# Altium schematic sheet DE1_SIDE_SDRAM.schdoc
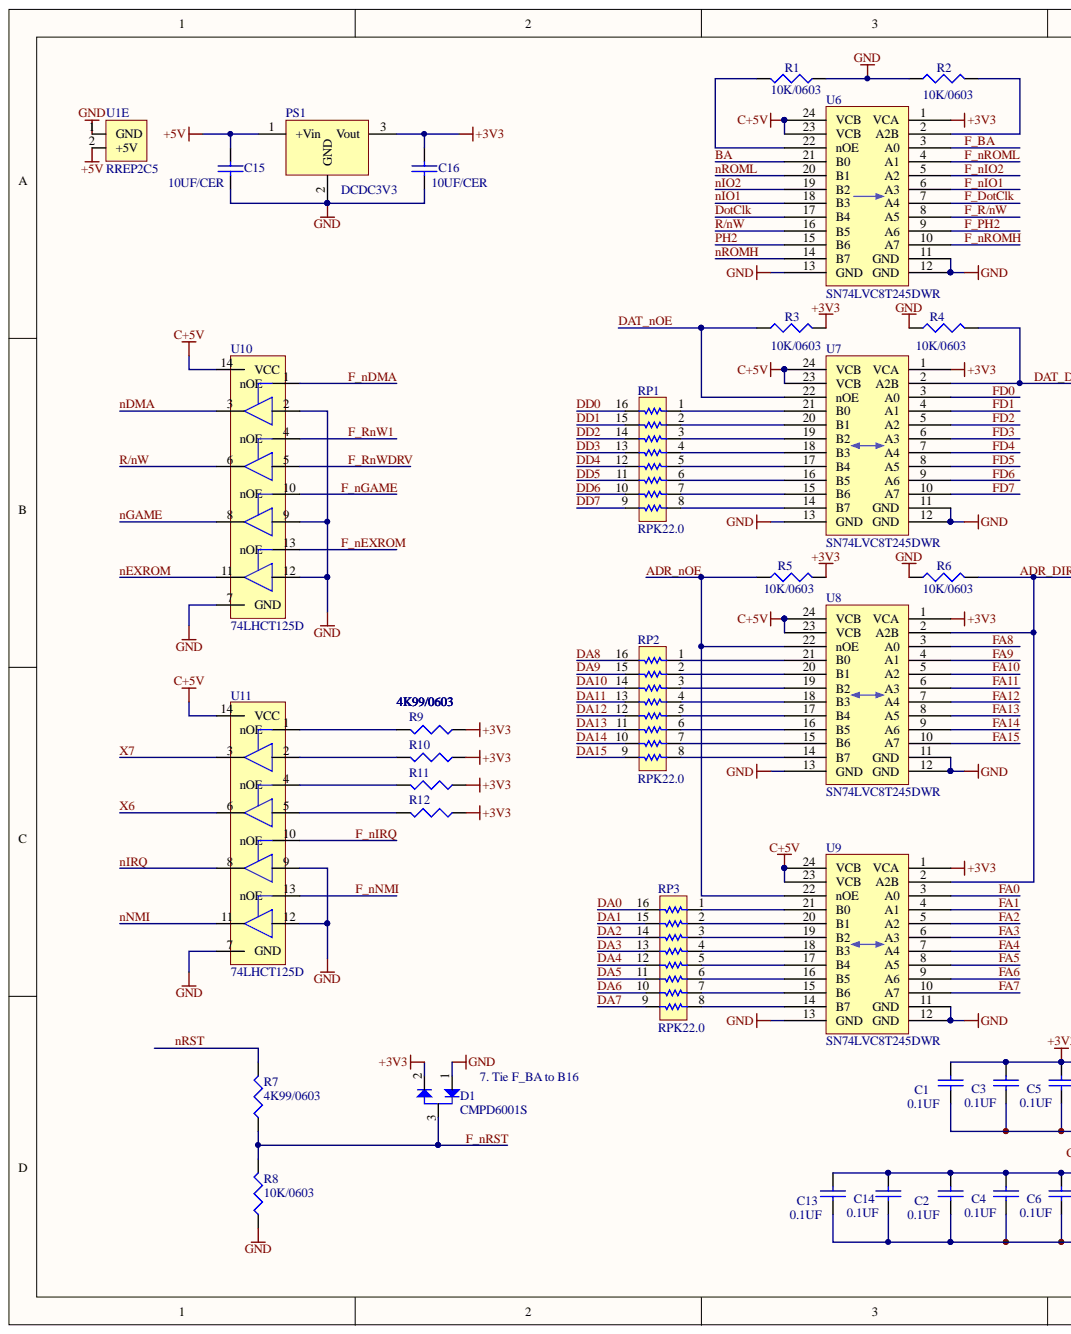
[diagram p0: DE1_SIDE_SDRAM.schdoc, left]
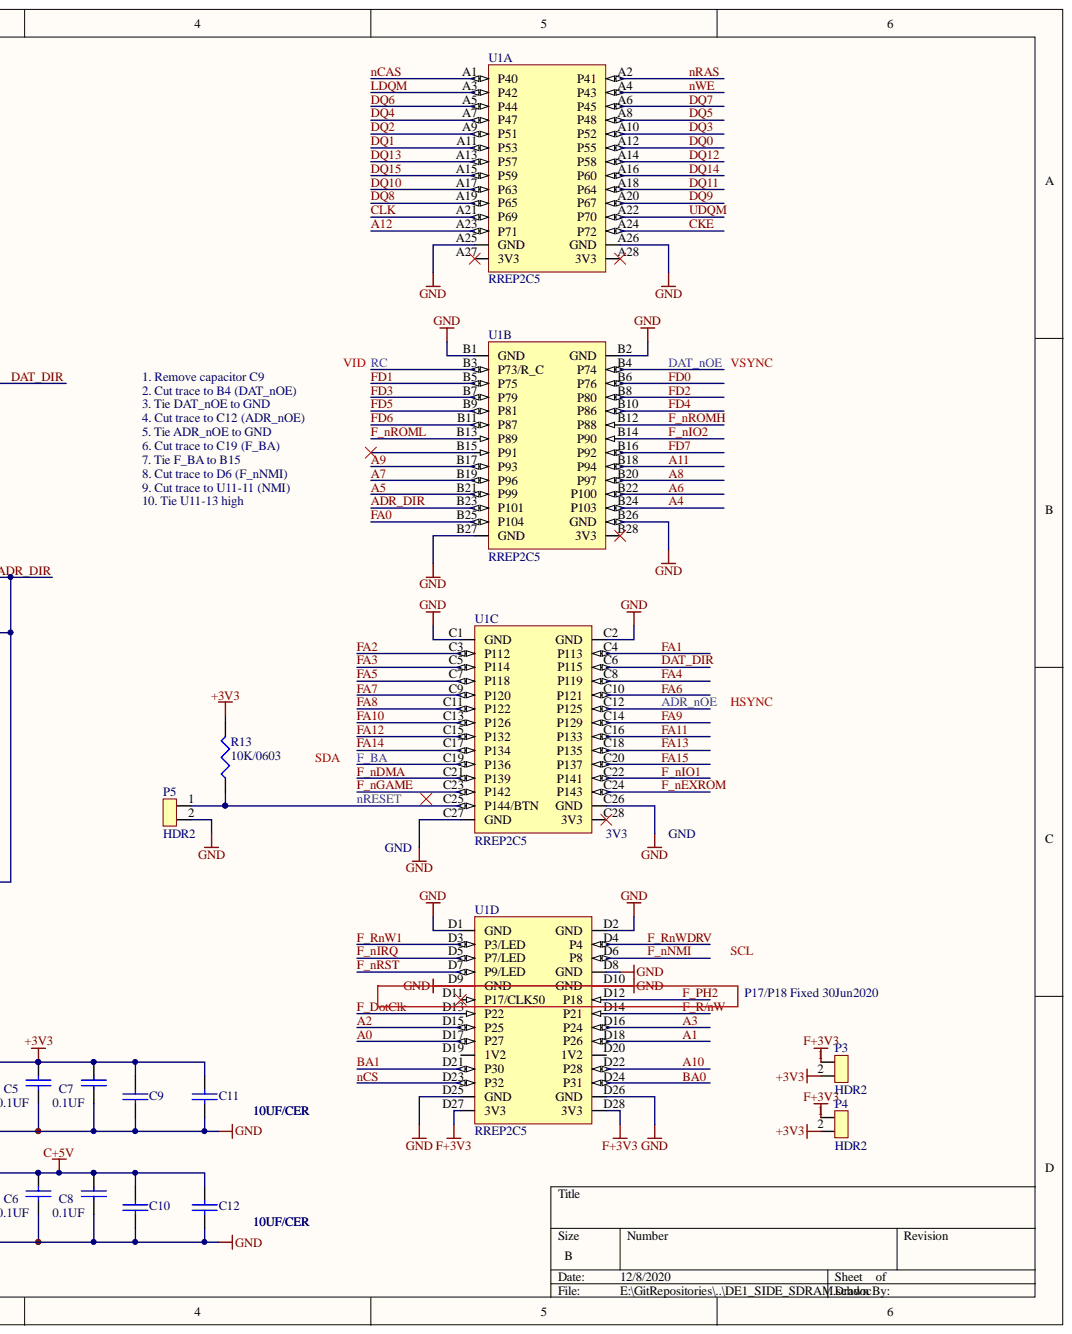
[diagram p1: DE1_SIDE_SDRAM.schdoc, right]

## Parts
C1  0.1UF  [0603]
C2  0.1UF  [0603]
C3  0.1UF  [0603]
C4  0.1UF  [0603]
C5  0.1UF  [0603]
C6  0.1UF  [0603]
C7  0.1UF  [0603]
C8  0.1UF  [0603]
C9  10UF/CER  [0805]  (value not drawn)
C10  10UF/CER  [0805]  (value not drawn)
C11  10UF/CER  [0805]
C12  10UF/CER  [0805]
C13  0.1UF  [0603]
C14  0.1UF  [0603]
C15  10UF/CER  [0805]
C16  10UF/CER  [0805]
D1  CMPD6001S  [SOT23]
P3  HDR2  [HDR2]
P4  HDR2  [HDR2]
P5  HDR2  [HDR2]
PS1  DCDC3V3  [CUI_P7805]
R1  10K/0603  [0603]
R2  10K/0603  [0603]
R3  10K/0603  [0603]
R4  10K/0603  [0603]
R5  10K/0603  [0603]
R6  10K/0603  [0603]
R7  4K99/0603  [0603]
R8  10K/0603  [0603]
R9  4K99/0603  [0603]
R10  4K99/0603  [0603]
R11  4K99/0603  [0603]
R12  4K99/0603  [0603]
R13  10K/0603  [0603]
RP1  RPK22.0  [RPK4816]
RP2  RPK22.0  [RPK4816]
RP3  RPK22.0  [RPK4816]
U1  RREP2C5  [RR2C5]  (parts A,B,C,D,E)
U6  SN74LVC8T245DWR  [SOL-24]
U7  SN74LVC8T245DWR  [SOL-24]
U8  SN74LVC8T245DWR  [SOL-24]
U9  SN74LVC8T245DWR  [SOL-24]
U10  74LHCT125D  [SOP14]
U11  74LHCT125D  [SOP14]

## Nets
+3V3: C1.1 C3.1 C5.1 C7.1 C9.2 C11.2 C16.2 D1.2 P3.2 P4.2 PS1.3 R3.2 R5.2 R9.1 R10.1 R11.1 R12.1 R13.2 U6.1(VCA) U7.1(VCA) U8.1(VCA) U9.1(VCA)
+5V: C15.2 PS1.1 U1.2(+5V)
C+5V: C2.1 C4.1 C6.1 C8.1 C10.2 C12.2 C13.1 C14.1 U6.23(VCB) U6.24(VCB) U7.23(VCB) U7.24(VCB) U8.23(VCB) U8.24(VCB) U9.23(VCB) U9.24(VCB) U10.14(VCC) U11.14(VCC)
F+3V3: P3.1 P4.1 U1.D27(3V3) U1.D28(3V3)
GND: C1.2 C2.2 C3.2 C4.2 C5.2 C6.2 C7.2 C8.2 C9.1 C10.1 C11.1 C12.1 C13.2 C14.2 C15.1 C16.1 D1.1 P5.2 PS1.2 R1.1 R2.1 R4.1 R6.1 R8.1 U1.1(GND) U1.A25(GND) U1.A26(GND) U1.B1(GND) U1.B2(GND) U1.B26(GND) U1.B27(GND) U1.C1(GND) U1.C2(GND) U1.C26(GND) U1.C27(GND) U1.D1(GND) U1.D2(GND) U1.D8(GND) U1.D9(GND) U1.D10(GND) U1.D25(GND) U1.D26(GND) U6.11(GND) U6.12(GND) U6.13(GND) U7.11(GND) U7.12(GND) U7.13(GND) U8.11(GND) U8.12(GND) U8.13(GND) U9.11(GND) U9.12(GND) U9.13(GND) U10.2 U10.7(GND) U10.9 U10.12 U11.7(GND) U11.9 U11.12
A0: U1.D17(P27)
A1: U1.D18(P26)
A2: U1.D15(P25)
A3: U1.D16(P24)
A4: U1.B24(P103)
A5: U1.B21(P99)
A6: U1.B22(P100)
A7: U1.B19(P96)
A8: U1.B20(P97)
A9: U1.B17(P93)
A10: U1.D22(P28)
A11: U1.B18(P94)
A12: U1.A23(P71)
ADR_DIR: R6.2 U1.B23(P101) U8.2(A2B) U9.2(A2B)
ADR_NOE: R5.1 U1.C12(P125) U8.22(nOE) U9.22(nOE)
BA: U6.21(B0)
BA0: U1.D24(P31)
BA1: U1.D21(P30)
CKE: U1.A24(P72)
CLK: U1.A21(P69)
DA0: RP3.16
DA1: RP3.15
DA2: RP3.14
DA3: RP3.13
DA4: RP3.12
DA5: RP3.11
DA6: RP3.10
DA7: RP3.9
DA8: RP2.16
DA9: RP2.15
DA10: RP2.14
DA11: RP2.13
DA12: RP2.12
DA13: RP2.11
DA14: RP2.10
DA15: RP2.9
DAT_DIR: R4.2 U1.C6(P115) U7.2(A2B)
DAT_NOE: R3.1 U1.B4(P74) U7.22(nOE)
DD0: RP1.16
DD1: RP1.15
DD2: RP1.14
DD3: RP1.13
DD4: RP1.12
DD5: RP1.11
DD6: RP1.10
DD7: RP1.9
DOTCLK: U6.17(B4)
DQ0: U1.A12(P55)
DQ1: U1.A11(P53)
DQ2: U1.A9(P51)
DQ3: U1.A10(P52)
DQ4: U1.A7(P47)
DQ5: U1.A8(P48)
DQ6: U1.A5(P44)
DQ7: U1.A6(P45)
DQ8: U1.A19(P65)
DQ9: U1.A20(P67)
DQ10: U1.A17(P63)
DQ11: U1.A18(P64)
DQ12: U1.A14(P58)
DQ13: U1.A13(P57)
DQ14: U1.A16(P60)
DQ15: U1.A15(P59)
F_BA: U1.C19(P136) U6.3(A0)
F_DOTCLK: U1.D13(P22) U6.7(A4)
F_NDMA: U1.C21(P139) U10.1(nOE)
F_NEXROM: U1.C24(P143) U10.13(nOE)
F_NGAME: U1.C23(P142) U10.10(nOE)
F_NIO1: U1.C22(P141) U6.6(A3)
F_NIO2: U1.B14(P90) U6.5(A2)
F_NIRQ: U1.D5(P7/LED) U11.10(nOE)
F_NNMI: U1.D6(P8) U11.13(nOE)
F_NROMH: U1.B12(P88) U6.10(A7)
F_NROML: U1.B13(P89) U6.4(A1)
F_NRST: D1.3 R7.1 R8.2 U1.D7(P9/LED)
F_PH2: U1.D12(P18) U6.9(A6)
F_R/NW: U1.D14(P21) U6.8(A5)
F_RNW1: U1.D3(P3/LED) U10.4(nOE)
F_RNWDRV: U1.D4(P4) U10.5
FA0: U1.B25(P104) U9.3(A0)
FA1: U1.C4(P113) U9.4(A1)
FA2: U1.C3(P112) U9.5(A2)
FA3: U1.C5(P114) U9.6(A3)
FA4: U1.C8(P119) U9.7(A4)
FA5: U1.C7(P118) U9.8(A5)
FA6: U1.C10(P121) U9.9(A6)
FA7: U1.C9(P120) U9.10(A7)
FA8: U1.C11(P122) U8.3(A0)
FA9: U1.C14(P129) U8.4(A1)
FA10: U1.C13(P126) U8.5(A2)
FA11: U1.C16(P133) U8.6(A3)
FA12: U1.C15(P132) U8.7(A4)
FA13: U1.C18(P135) U8.8(A5)
FA14: U1.C17(P134) U8.9(A6)
FA15: U1.C20(P137) U8.10(A7)
FD0: U1.B6(P76) U7.3(A0)
FD1: U1.B5(P75) U7.4(A1)
FD2: U1.B8(P80) U7.5(A2)
FD3: U1.B7(P79) U7.6(A3)
FD4: U1.B10(P86) U7.7(A4)
FD5: U1.B9(P81) U7.8(A5)
FD6: U1.B11(P87) U7.9(A6)
FD7: U1.B16(P92) U7.10(A7)
LDQM: U1.A3(P42)
NCAS: U1.A1(P40)
NCS: U1.D23(P32)
NDMA: U10.3
NEXROM: U10.11
NGAME: U10.8
NIO1: U6.18(B3)
NIO2: U6.19(B2)
NIRQ: U11.8
NNMI: U11.11
NRAS: U1.A2(P41)
NRESET: P5.1 R13.1 U1.C25(P144/BTN)
NROMH: U6.14(B7)
NROML: U6.20(B1)
NRST: R7.2
NWE: U1.A4(P43)
PH2: U6.15(B6)
R/NW: U6.16(B5) U10.6
RC: U1.B3(P73/R_C)
UDQM: U1.A22(P70)
X6: U11.6
X7: U11.3
NetR1_2: R1.2 U6.22(nOE)
NetR2_2: R2.2 U6.2(A2B)
NetR9_2: R9.2 U11.1(nOE)
NetR10_2: R10.2 U11.2
NetR11_2: R11.2 U11.4(nOE)
NetR12_2: R12.2 U11.5
NetRP1_1: RP1.1 U7.21(B0)
NetRP1_2: RP1.2 U7.20(B1)
NetRP1_3: RP1.3 U7.19(B2)
NetRP1_4: RP1.4 U7.18(B3)
NetRP1_5: RP1.5 U7.17(B4)
NetRP1_6: RP1.6 U7.16(B5)
NetRP1_7: RP1.7 U7.15(B6)
NetRP1_8: RP1.8 U7.14(B7)
NetRP2_1: RP2.1 U8.21(B0)
NetRP2_2: RP2.2 U8.20(B1)
NetRP2_3: RP2.3 U8.19(B2)
NetRP2_4: RP2.4 U8.18(B3)
NetRP2_5: RP2.5 U8.17(B4)
NetRP2_6: RP2.6 U8.16(B5)
NetRP2_7: RP2.7 U8.15(B6)
NetRP2_8: RP2.8 U8.14(B7)
NetRP3_1: RP3.1 U9.21(B0)
NetRP3_2: RP3.2 U9.20(B1)
NetRP3_3: RP3.3 U9.19(B2)
NetRP3_4: RP3.4 U9.18(B3)
NetRP3_5: RP3.5 U9.17(B4)
NetRP3_6: RP3.6 U9.16(B5)
NetRP3_7: RP3.7 U9.15(B6)
NetRP3_8: RP3.8 U9.14(B7)

Not connected: U1 A27(3V3) A28(3V3) B15(P91) B28(3V3) C28(3V3) D11(P17/CLK50) D19(1V2) D20(1V2)

## Library data of the parts
C1-C8 C13 C14 = 0.1UF: CAP .10UF 16V CERAMIC X7R 0603; Text Field1: Kemet; Text Field2: C0603C104K4RACTU; Text Field3: 399-1096-1-ND; Text Field4: 1.17; Component Kind: Standard
C9-C12 C15 C16 = 10UF/CER: 10µF ±10% 25V Ceramic Capacitor X7S 0805; Text Field1: Murata; Text Field2: GRM21BC71E106KE11L; Text Field3: 490-10496-1-ND; Component Kind: Standard
D1 = CMPD6001S: Diode Array 1 Pair Series Connection Standard 75V 250mA Surface Mount TO-236-3, SC-59, SOT-23-3; DK PN: CMPD6001S CT-ND; MFG: Central Semiconductor; MFG PN: CMPD6001S TR; Component Kind: Standard; Library Name: C64Interface.SchLib
PS1 = DCDC3V3: Linear Regulator Replacement DC DC Converter 1 Output 3.3V 500mA 4.75V - 28V Input; DK PN: 102-2704-ND; MFG: CUI; MFG PN: P7805-Q24-S3-S; Component Kind: Standard; Library Name: APN.SchLib
R1-R6 R13 = 10K/0603: RES 10.0K OHM 1/10W 1% 0603 SMD; Text Field1: Dale/Vishay; Text Field2: CRCW060310K0FKEA; Text Field3: 541-10.0KHCT-ND; Text Field4: 5.02; Component Kind: Standard
R7 R9-R12 = 4K99/0603: RES 4.99K OHM 1/10W 1% 0603 SMD; DK PN: 541-3985-1-ND; MFG: Dale/Vishay; MFG PN: CRCW06034K99FKEAC; Component Kind: Standard; Library Name: C64Interface.SchLib; Price: 5.02
R8 = 10K/0603: RES 10.0K OHM 1/10W 1% 0603 SMD; DK PN: 541-10.0KHCT-ND; MFG: Dale/Vishay; MFG PN: CRCW060310K0FKEA; Component Kind: Standard; Library Name: C64Interface.SchLib; Price: 5.02
RP1-RP3 = RPK22: 22 Ohm ±1Ohm 160mW Power Per Element Isolated Resistor Network/Array ±250ppm/°C 16-SOIC (0.220", 5.59mm Width); DK PN: 4816P-1-220LFCT-ND; MFG: Bourns; MFG PN: 4816P-1-220LF; Component Kind: Standard; Library Name: C64Interface.SchLib; Price: 1.31
U1 = RREP2C5: RioRand EP2C5T144 Altera Cyclone II FPGA Mini Development Board; Component Kind: Standard; Library Name: C64Interface.SchLib
U6-U9 = SN74LVC8T245DWR: Voltage Level Translator Bidirectional 1 Circuit 8 Channel 24-SOIC; DK PN: 296-23280-1-ND; MFG: TI; Component Kind: Standard; Library Name: C64Interface.SchLib; MFG PN: SN74LVC8T245DWR; Price: 1.02
U10 U11 = 74LHCT125D: Buffer, Non-Inverting 4 Element 1 Bit per Element 3-State Output 14-SOIC; DK PN: 1727-2834-2-ND; MFG: Nexpiria; MFG PN: 74HCT125D,653; Component Kind: Standard; Library Name: C64Interface.SchLib; Price: 1.17
P3-P5 = HDR2: Component Kind: Standard; Library Name: C64Interface.SchLib
